# Altium schematic sheet SENSOR.SchDoc(SENSOR)
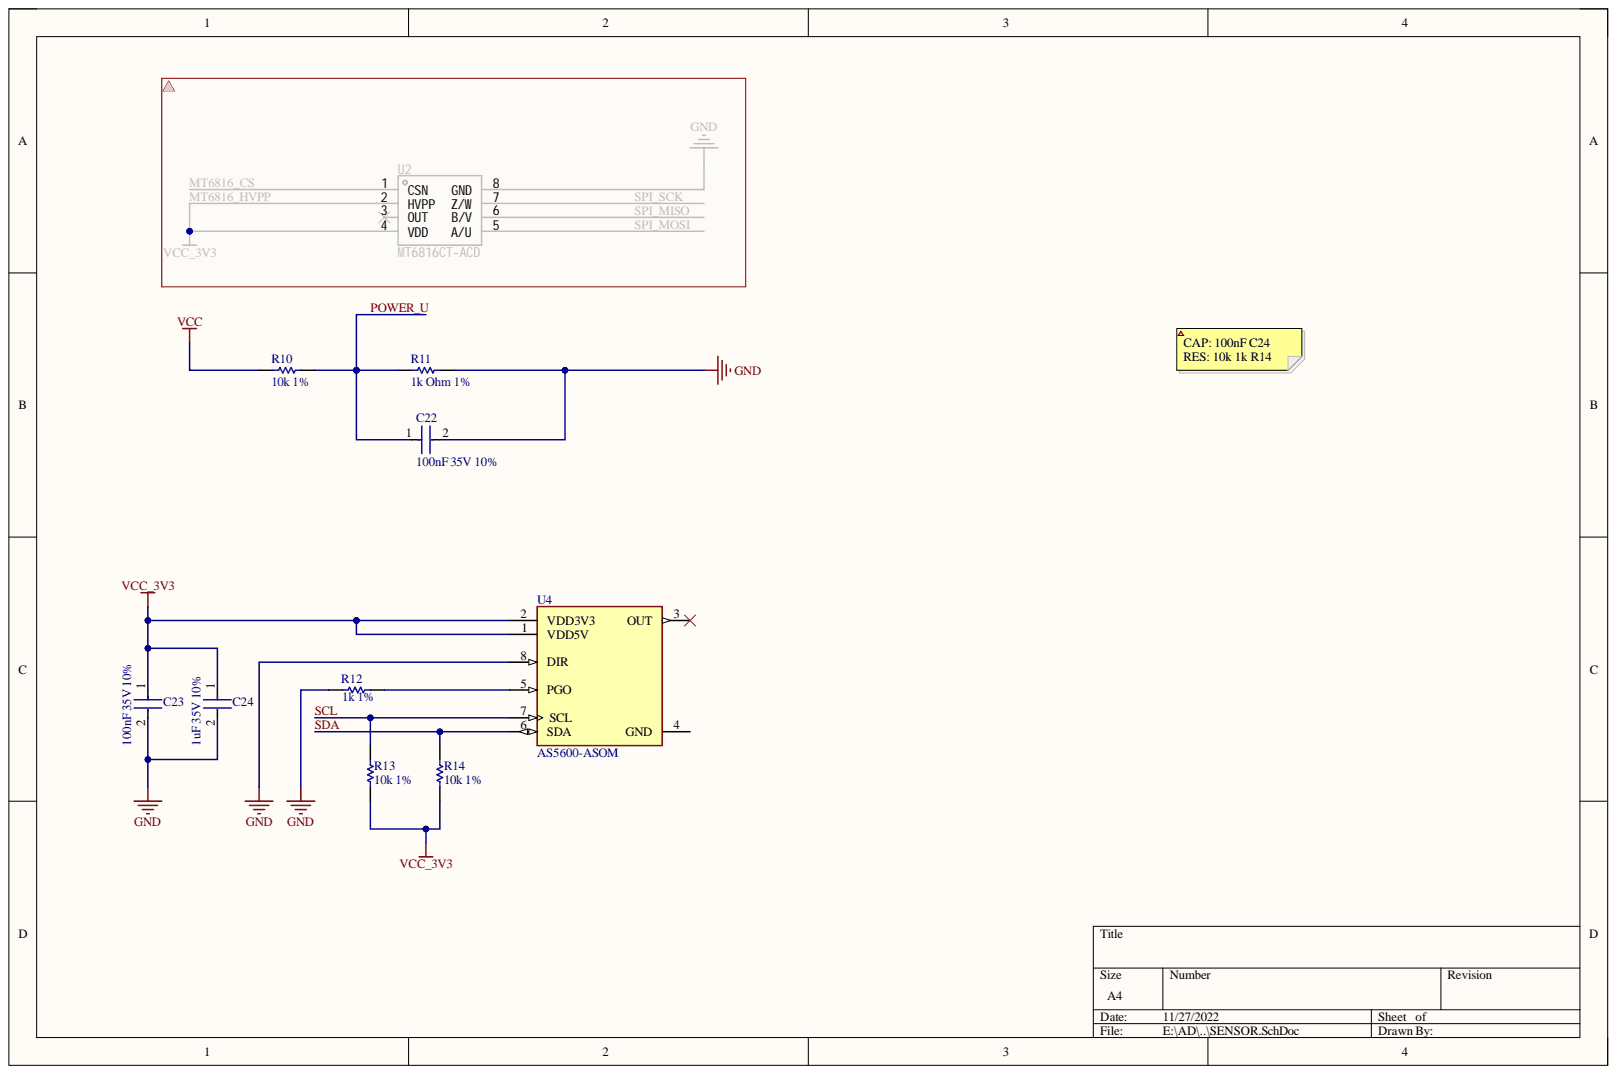
[diagram p0: SENSOR.SchDoc]

## Parts
C22  100nF 35V 10%  Capacitance: 100nF  Voltage: 35V  [FP-0402-L_1_0_0_05-W_0_5-IPC_A]
C23  100nF 35V 10%  Capacitance: 100nF  Tolerance: 10%  Voltage: 35V  [FP-0402-L_1_0_0_05-W_0_5-IPC_A]
C24  1uF 35V 10%  Capacitance: 1uF  Tolerance: 10%  Voltage: 35V  [FP-0805-L_2_0_0_1-W_1_25-IPC_C]
R10  10k 1%  [RESC2013X70X40ML20T20]
R11  1k Ohm 1%  Tolerance: 1%  [RESC2013X60X35ML10T20]
R12  1k 1%  [RESC3216X70X50LL05T20]
R13  10k 1%  [RESC2013X70X40ML20T20]
R14  10k 1%  [RESC2013X70X40ML20T20]
U4  AS5600-ASOM  [FP-AS5600-ASOM-IPC_C]

## Nets
GND: C22.2 C23.2 C24.2 R11.2 R12.1 U4.8(DIR)
VCC: R10.2
VCC_3V3: C23.1 C24.1 R13.1 R14.1 U4.1(VDD5V) U4.2(VDD3V3)
POWER_U: C22.1 R10.1 R11.1
SCL: R13.2 U4.7(SCL)
SDA: R14.2 U4.6(SDA)
NetR12_2: R12.2 U4.5(PGO)

Not connected: U4 3(OUT) 4(GND)

## Library data of the parts
C22 C23 = CMP-2000-06323-3: Dielectric: X5R; Case Code (Imperial): 0402; Case Code (Metric): 1005; Case/Package: 0402; Component Kind: Standard; Construction: Flat; Depth: 0.5mm; Description: 100nF 35V 10%; Halogen Free: Halogen Free; Height: 0.5mm; Length: 1mm; Library Name: Altium Content Vault; Max Operating Temperature: 85°C; Min Operating Temperature: -55°C; Mount: Surface Mount; Number of Pins: 2; Package Quantity: 1; Packaging: Tape and Reel; Radiation Hardening: No; REACH SVHC: No SVHC; Resistor Type: Ceramic; RoHS Compliant: Yes; Series: M; Simulation: GMK105BJ104_V-F; Termination: SMD/SMT; Thickness: 0.022inch; Voltage Rating: 35V; Voltage Rating (DC): 35V; Width: 0.02inch
  C22: Tolerance: 10%
C24 = CMP-14477-004038-2: Multilayer Ceramic Capacitor 1 uF 35V X7R 10% SMD 0805 T/R; Case Code (Imperial): 0805; Case Code (Metric): 2012; Case/Package: 0805; Component Kind: Standard; Construction: Flat; Contact Plating: Nickel; Depth: 1.25mm; Dielectric: X7R; Halogen Free: Halogen Free; Height: 1.25mm; Lead Free: Lead Free; Length: 2mm; Library Name: Altium Content Vault; Max Operating Temperature: 125°C; Min Operating Temperature: -55°C; Mount: Surface Mount; Number of Pins: 2; Package Quantity: 1; Packaging: Tape and Reel; Radiation Hardening: No; RoHS Compliant: Yes; Series: M; Termination: SMD/SMT; Thickness: 0.053inch; Voltage Rating: 35V; Voltage Rating (DC): 35V; Width: 0.049inch
R10 R13 R14 = CMP-2001-04376-1: Manufacturer: Panasonic; Part Number: ERJ-6ENF1002V; Component Kind: Standard; Library Name: Altium Content Vault
R11 = CMP-2100-03671-1: Chip Resistor, 1 KOhm, +/- 1%, 125 mW, -55 to 155 degC, 0805 (2012 Metric), RoHS, Tape and Reel; Manufacturer: Yageo; Max Power Dissipation: 125mW; Resistance: 1KOhm; Temperature Coefficient: 100ppm/degreesC; Voltage Rating: 150V; Case Code (Imperial): 0805; Case Code (Metric): 2012; Case/Package: 0805; Component Kind: Standard; Composition: ThickFilm; Datasheet URL: http://www.yageo.com/NewPortal/yageodocoutput?fileName=/pdf/R-Chip/PYu-RC_Group_51_RoHS_L_5.pdf; Datasheet Version: Rev. 5, 10/2016; Depth: 1.25mm; Height: 0.024inch; Lead Free: LeadFree; Length: 0.079inch; Library Name: Altium Content Vault; Manufacturer URL: http://www.yageo.com/; Max Operating Temperature: 155degC; Military Standard: Not; Min Operating Temperature: -55degC; Number of Pins: 2; Number of Terminations: 2; Package Description: 2-Pin Surface Mounted Device, Body 2 x 1.25 mm; Package Quantity: 1; Package Reference: 0805; Packaging: TapeandReel; Power Rating: 125mW; REACH SVHC: Unknown; RoHSCompliant: true; Termination: SMD/SMT; Voltage Rating (DC): 150V; Width: 0.049inch
R12 = CMP-2003-00017-1: Manufacturer: Panasonic; Part Number: ERJ-8ENF1001V; Component Kind: Standard; Library Name: Altium Content Vault
U4 = CMP-22826-000002-1: SENSOR ANGLE 360DEG SMD; Sensing Method: Hall Effect; Component Kind: Standard; Lead Free: Lead Free; Library Name: Altium Content Vault; Max Operating Temperature: 125°C; Min Operating Temperature: -40°C; Mount: Surface Mount; Termination: SMD/SMT
